# Altium schematic sheet Sheet3.SchDoc(Sheet3)
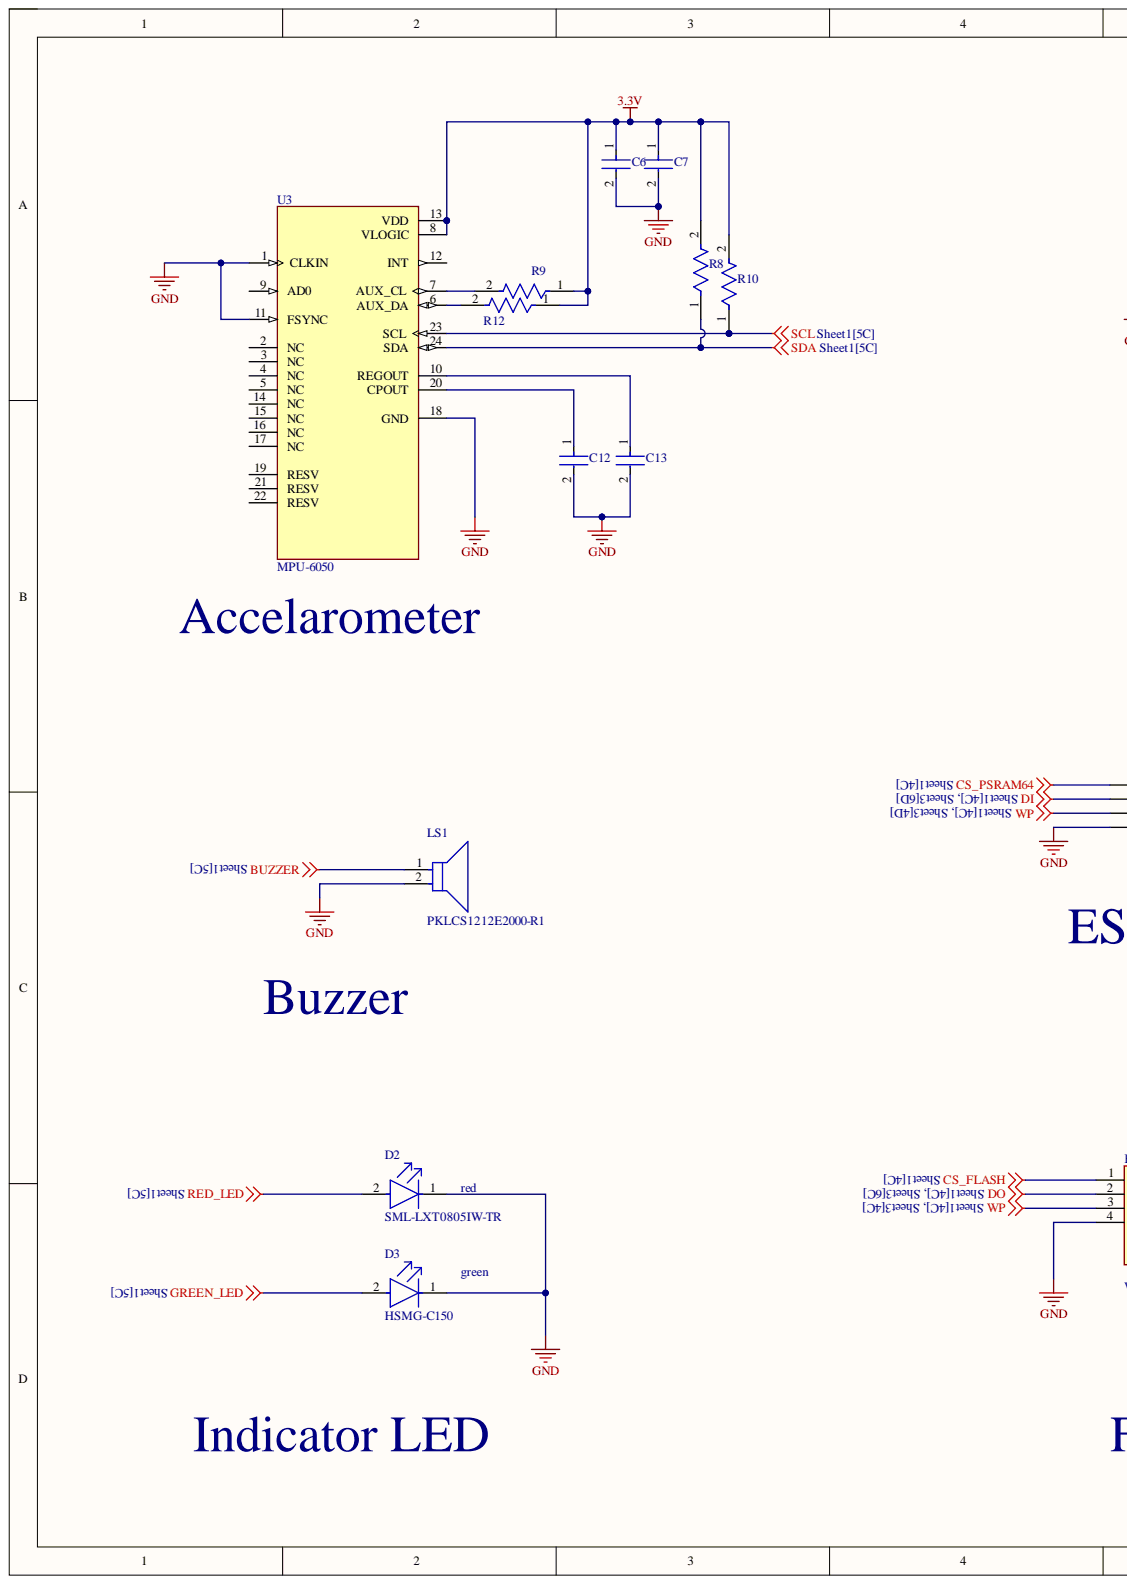
[diagram p0: Sheet3.SchDoc, left]
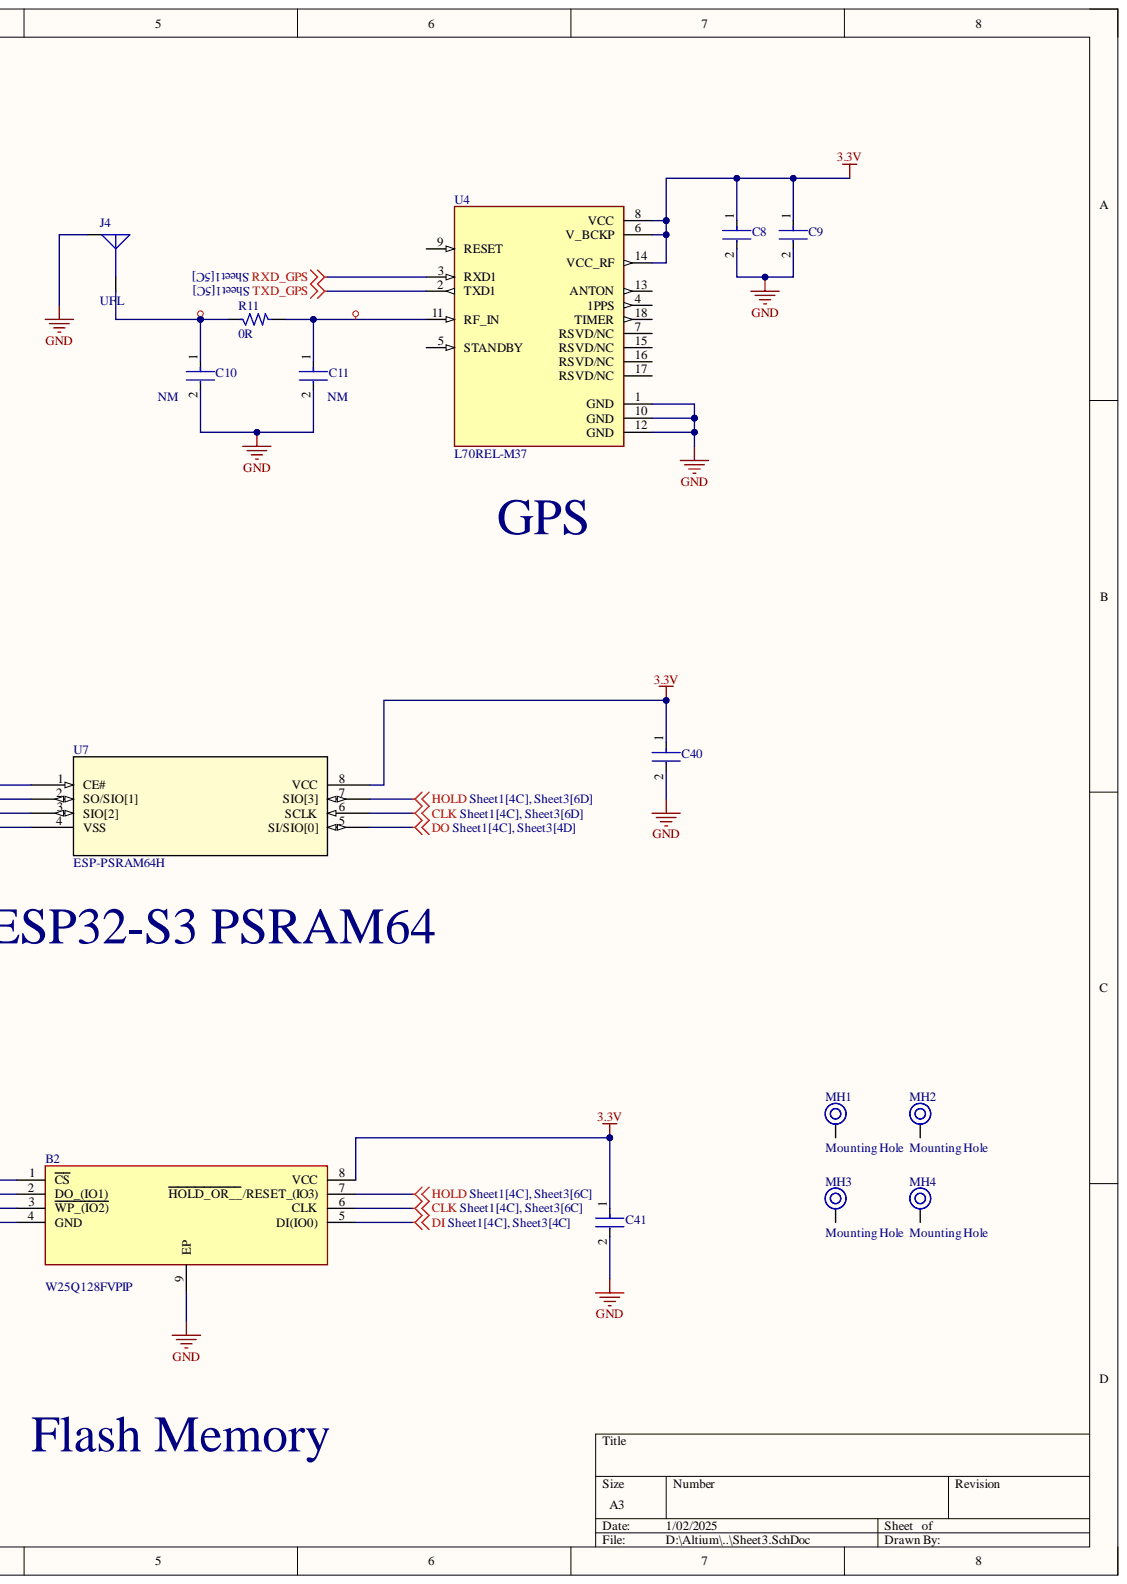
[diagram p1: Sheet3.SchDoc, right]

## Parts
B2  W25Q128FVPIP  [SON127P600X500X80-9N-D]
C6  C0402C104K4RACTU  [FP-C0402-BB-MFG]  (value not drawn)
C7  C0402C104K4RACTU  [FP-C0402-BB-MFG]  (value not drawn)
C8  C0402C104K4RACTU  [FP-C0402-BB-MFG]  (value not drawn)
C9  C0402C104K4RACTU  [FP-C0402-BB-MFG]  (value not drawn)
C10  04025C222KAT2A  [FP-0402-L_1_0_1-W_0_5_0_1-MFG]  (value not drawn)
C11  C0402C104K4RACTU  [FP-C0402-BB-MFG]  (value not drawn)
C12  04025C222KAT2A  [FP-0402-L_1_0_1-W_0_5_0_1-MFG]  (value not drawn)
C13  C0402C104K4RACTU  [FP-C0402-BB-MFG]  (value not drawn)
C40  C0402C104K4RACTU  [FP-C0402-BB-MFG]  (value not drawn)
C41  C0402C104K4RACTU  [FP-C0402-BB-MFG]  (value not drawn)
D2  SML-LXT0805IW-TR  [FP-SML-LXT0805IW-TR-MFG]
D3  HSMG-C150  [FP-HSMG-C150-MFG]
J4  UFL  [MOLEX SD-734120110]
LS1  PKLCS1212E2000-R1  [FP-PKLCS1212E2000-R1-MFG]
MH1  Mounting Hole  [MOUNTING]
MH2  Mounting Hole  [MOUNTING]
MH3  Mounting Hole  [MOUNTING]
MH4  Mounting Hole  [MOUNTING]
R8  CRCW04024K70FKED  [FP-CRCW0402-e3-IPC_B]  (value not drawn)
R9  CRCW04024K70FKED  [FP-CRCW0402-e3-IPC_B]  (value not drawn)
R10  CRCW04024K70FKED  [FP-CRCW0402-e3-IPC_B]  (value not drawn)
R11  0R  [RES_0805]
R12  CRCW04024K70FKED  [FP-CRCW0402-e3-IPC_B]  (value not drawn)
U3  MPU-6050  [QFN50P400X400X95-24N]
U4  L70REL-M37  [XCVR_L70REL-M37]
U7  ESP-PSRAM64H  [SOP8-150MIL_ADA]

## Nets
3.3V: B2.8(VCC) C6.1 C7.1 C8.1 C9.1 C40.1 C41.1 R8.2 R9.1 R10.2 R12.1 U3.8(VLOGIC) U3.13(VDD) U4.6(V_BCKP) U4.8(VCC) U4.14(VCC_RF) U7.8(VCC)
BUZZER: LS1.1
CLK: B2.6(CLK) U7.6(SCLK)
CS_FLASH: B2.1(CS)
CS_PSRAM64: U7.1(CE#)
DI: B2.5(DI(IO0)) U7.2(SO/SIO[1])
DO: B2.2(DO_(IO1)) U7.5(SI/SIO[0])
GND: B2.4(GND) B2.9(EP) C6.2 C7.2 C8.2 C9.2 C10.2 C11.2 C12.2 C13.2 C40.2 C41.2 D2.1 D3.1 J4.G LS1.2 U3.1(CLKIN) U3.11(FSYNC) U3.18(GND) U4.1(GND) U4.10(GND) U4.12(GND) U7.4(VSS)
GREEN_LED: D3.2
HOLD: B2.7(HOLD_OR__/RESET_(IO3)) U7.7(SIO[3])
RED_LED: D2.2
RXD_GPS: U4.3(RXD1)
SCL: R10.1 U3.23(SCL)
SDA: R8.1 U3.24(SDA)
TXD_GPS: U4.2(TXD1)
WP: B2.3(WP_(IO2)) U7.3(SIO[2])
NetC10_1: C10.1 J4.S R11.1
NetC11_1: C11.1 R11.2 U4.11(RF_IN)
NetC12_1: C12.1 U3.20(CPOUT)
NetC13_1: C13.1 U3.10(REGOUT)
NetR9_2: R9.2 U3.7(AUX_CL)
NetR12_2: R12.2 U3.6(AUX_DA)

Not connected: MH1 1; MH2 1; MH3 1; MH4 1; U3 2(NC) 3(NC) 4(NC) 5(NC) 9(AD0) 12(INT) 14(NC) 15(NC) 16(NC) 17(NC) 19(RESV) 21(RESV) 22(RESV); U4 4(1PPS) 5(STANDBY) 7(RSVD/NC) 9(RESET) 13(ANTON) 15(RSVD/NC) 16(RSVD/NC) 17(RSVD/NC) 18(TIMER)

## Library data of the parts
B2 = W25Q128FVPIP: 3V 128M-BIT Serial Flash Memory With Dual/Quad SPI & QPI; Manufacturer_Name: Winbond; Geometry.Height: 0.8mm; Component Kind: Standard; Library Name: W25Q128FVPIP.SchLib; Manufacturer_Part_Number: W25Q128FVPIP
C6-C9 C11 C13 C40 C41 = CMP-2008-02108-2: CAP CER 0.1UF 16V X7R 0402; Manufacturer: Kemet; Capacitance: 0.10 μF; Material: Ceramic; Temperature Coefficient: 15%; Tolerance: 0.1; Value: 0.10 μF; Automotive: No; Category: Cap; Component Kind: Standard; ComponentLink2Description: Datasheet; ComponentLink2URL: https://content.kemet.com/datasheets/KEM_C1002_X7R_SMD.pdf; Device Class L1: Passive Components; Device Class L2: Capacitors; Device Class L3: Ceramic Capacitors; Digikey Description: CAP CER 0.1UF 16V X7R 0402; Height: 0.55mm; Ipc Land Pattern Name: CAPC10050X50; Lead Free: Yes; Library Name: Altium Content Vault; Manufacturer Part Number: C0402C104K4RACTU; Mouser Description: Cap Ceramic 0.1uF 16V X7R 10% SMD 0402 125?C Paper T/R - Tape and Reel (Alt: C0402C104K4RAC TU); Octopart: https://octopart.com/c0402c104k4ractu-kemet-94158; Package: C0402-BB; RoHS: TRUE; Temperature: -55°C to +125°C; Temperature Characteristic: X7R; Temperature Range High: +125°C; Temperature Range Low: -55°C; Voltage Rating: 16 V
C10 C12 = CMP-2008-02711-2: General Purpose Ceramic Capacitor, 0402, 2.2nF, 10%, X7R, 15%, 50V; Capacitance: 2.2nF; Case Code (Imperial): 0402; Case Code (Metric): 1005; Case/Package: 0402; Component Kind: Standard; Construction: Flat; Contact Plating: Tin; Depth: 0.5mm; Dielectric: X7R; Dielectric Material: Ceramic; Height: 0.56mm; Lead Free: Lead Free; Length: 1mm; Library Name: Altium Content Vault; Max Operating Temperature: 125°C; Min Operating Temperature: -55°C; Mount: Surface Mount; Number of Pins: 2; Package Quantity: 1; Packaging: Tape and Reel; Radiation Hardening: No; REACH SVHC: No SVHC; RoHS Compliant: Yes; Series: -; Termination: SMD/SMT; Thickness: 0.022inch; Tolerance: 10%; Voltage: 50V; Voltage Rating: 50V; Voltage Rating (DC): 50V; Width: 0.02inch
D2 = CMP-1724-00002-2: LED RED DIFFUSED SMD; Approvals: UL; Dominant Wavelength: 635nm; Forward Current: 20mA; Forward Voltage: 2V; Lens Color: White; Lens Style: Rectangular; Luminous Intensity: 11mcd; Number of LEDs: 1; Viewing Angle: 140°; Case Code (Imperial): 0805; Case Code (Metric): 2012; Case/Package: 0805; Color: Red; Component Kind: Standard; Height: 0.8mm; Illumination Color: Red; Lead Free: Lead Free; Length: 2mm; Lens Transparency: Diffused; Library Name: Altium Content Vault; Max Operating Temperature: 80°C; Min Operating Temperature: -25°C; Mount: Surface Mount; Number of Pins: 2; Package Quantity: 1; Packaging: Tape and Reel; REACH SVHC: No SVHC; Test Current: 20mA; Wavelength: 635nm; Width: 1.25mm
D3 = CMP-2000-05061-2: LED GREEN DIFFUSED 1206 SMD; Manufacturer: Broadcom; Forward Current: 20mA; Forward Voltage: 2.2V; Led Orientation: Top View; Luminous Intensity: 15mcd; Max Junction Temp: +95°C; Peak Emmision Wavelength: 570nm; Power Dissipation: 65mW; Reverse Voltage: 5V; Viewing Angle: 170°; Wavelength: 572nm; Automotive: No; Category: Diode; Colour: Green; Component Kind: Standard; ComponentLink2Description: Datasheet; ComponentLink2URL: https://docs.broadcom.com/docs/AV02-0551EN; Device Class L1: Optoelectronics; Device Class L2: LEDs; Device Class L3: unset; Digikey Description: LED GREEN DIFFUSED 1206 SMD; Height: 1.2mm; Ipc Land Pattern Name: DIOCP320160X55; Lead Free: Yes; Library Name: Altium Content Vault; Manufacturer Part Number: HSMG-C150; Mouser Description: LED Uni-Color Green 570nm 2-Pin Chip LED T/R; Octopart: https://octopart.com/hsmg-c150-broadcom-71131304; Package: HSMG-C150; RoHS: TRUE; Temperature: -40°C to +85°C; Temperature Range High: +85°C; Temperature Range Low: -40°C
J4 = UFL MOLEX SD-734120110: Component Kind: Standard; Library Name: Conn RF.IntLib; PartNumber: MOLEX SD-734120110
LS1 = CMP-06202-000001-1: AUDIO PIEZO TRANSDUCER 12.5V SMD; Manufacturer: Murata; Frequency: 2kHz; Automotive: No; Category: Speak; Component Kind: Standard; ComponentLink2Description: Datasheet; ComponentLink2URL: https://www.murata.com/en-global/products/productdata/8801054425118/SPEC-PKLCS1212E2000-R1.pdf?1517839212000; Device Class L1: Electromechanical; Device Class L2: Audio Products; Device Class L3: Buzzers; Digikey Description: AUDIO PIEZO TRANSDUCER 12.5V SMD; Height: 3mm; Lead Free: Yes; Library Name: Altium Content Vault; Manufacturer Part Number: PKLCS1212E2000-R1; Mouser Description: Piezo buzzer SMD; Octopart: https://octopart.com/pklcs1212e2000-r1-murata-7123179; Operating Supply Voltage: 12.5V; Package: PKLCS1212E2000-R1; RoHS: TRUE; Temperature: -20°C to +70°C; Temperature Range High: +70°C; Temperature Range Low: -20°C
MH1-MH4 = MH_3.5H_6D: Alternate Part Number-1: NA; Case/Package: TH; Component Kind: Standard; Description: Mounting Hole; Library Name: ESTEEMPCBLIB.IntLib; Manufacturer: NA; Manufacturer Part Number: NA; Supplier Part Number-1: NA; Supplier-1: NA
R8-R10 R12 = CMP-2002-00051-3: RES Thick Film, 4.7kΩ, 1%, 0.063W, 100ppm/°C, 0402; Max Power Dissipation: 63mW; Resistance: 4.7kR; Voltage Rating: 50V; Case Code (Imperial): 0402; Case Code (Metric): 1005; Case/Package: 0402; Component Kind: Standard; Composition: Thick Film; Contact Plating: Tin; Depth: 0.5mm; Features: Automotive AEC-Q200; Height: 0.35mm; Lead Free: Lead Free; Length: 1mm; Library Name: Altium Content Vault; Max Operating Temperature: 155°C; Military Standard: Not; Min Operating Temperature: -55°C; Mount: Surface Mount; Number of Terminations: 2; Package Quantity: 10; Packaging: Tape and Reel; Power Rating: 63mW; Radiation Hardening: No; Temperature Coefficient: 100ppm/°C; Termination: SMD/SMT; Tolerance: 1%; Voltage Rating (DC): 50V; Weight: 2.3E-05oz; Width: 0.5mm
R11 = RES_0R-0.125W-1%-0805: 0 Ohms Jumper 0.125W, 1/8W Chip Resistor 0805 (2012 Metric) Automotive AEC-Q200 Thick Film; Alternate Part Number-1: WR08X000PTL; Manufacturer: Walsin; Case/Package: 0805; Component Kind: Standard; Library Name: ESTEEMPCBLIB.IntLib; Manufacturer Part Number: WR08X000PTL; Supplier Part Number-1: WR08X000PTL; Supplier-1: SIRICOM
U3 = MPU-6050: MPU-6050 series Accelerometer, Gyroscope, 3 Axis Sensor Evaluation Board; MF: TDK InvenSense; Check_prices: https://www.snapeda.com/parts/MPU-6050/TDK+InvenSense/view-part/?ref=eda; Component Kind: Standard; Library Name: MPU-6050.IntLib; MP: MPU-6050; Package: QFN-24 InvenSense; SnapEDA_Link: https://www.snapeda.com/parts/MPU-6050/TDK+InvenSense/view-part/?ref=snap
U4 = L70REL-M37: Navigation GPS Transceiver Module 1.575GHz Antenna Not Included Surface Mount; MF: Quectel; Availability: In Stock; Check_prices: https://www.snapeda.com/parts/L70REL-M37/Quectel/view-part/?ref=eda; Component Kind: Standard; Library Name: GPS.IntLib; MP: L70REL-M37; Package: NON STANDARD-18 Quectel; Price: None; Purchase-URL: https://pricing.snapeda.com/search?q=L70REL-M37&ref=eda; SnapEDA_Link: https://www.snapeda.com/parts/L70REL-M37/Quectel/view-part/?ref=snap
U7 = ESPRESSIF ESP-PSRAM64H: No Description Available; Mfr_Name: Adafruit Industries; Component Kind: Standard; RefDes: RefDes; Type: Type; Library Name: ESPRESSIFESP-PSRAM64H.SchLib
